# Altium schematic sheet Cell5_Management.SchDoc
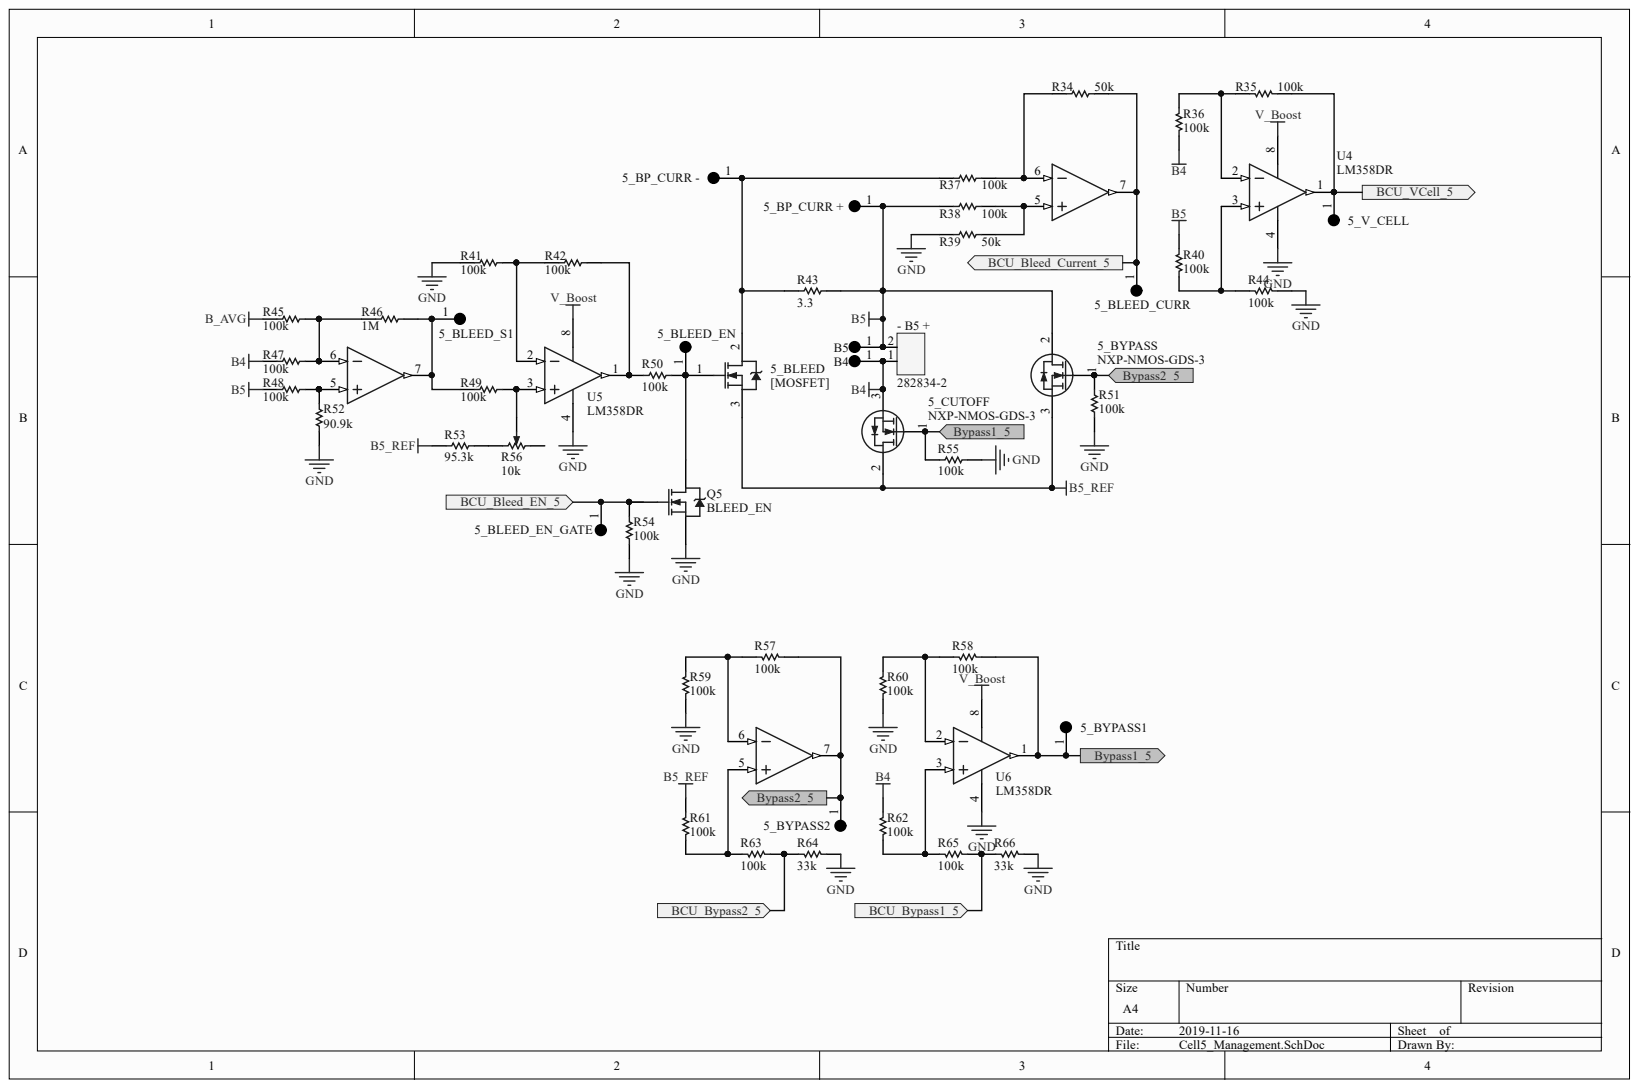
[diagram p0: Cell5_Management.SchDoc]

## Parts
5_BLEED  [MOSFET]  [PCB-8xisw4wyyimpbfb4c87f-1]
5_BLEED_CURR  Keystone 5011  [PCB-nje03k9v44gt2snsz3w9-1]  (value not drawn)
5_BLEED_EN  Keystone 5011  [PCB-nje03k9v44gt2snsz3w9-1]  (value not drawn)
5_BLEED_EN_GATE  Keystone 5011  [PCB-nje03k9v44gt2snsz3w9-1]  (value not drawn)
5_BLEED_S1  Keystone 5011  [PCB-nje03k9v44gt2snsz3w9-1]  (value not drawn)
5_BP_CURR_+  Keystone 5011  [PCB-nje03k9v44gt2snsz3w9-1]  (value not drawn)
5_BP_CURR_-  Keystone 5011  [PCB-nje03k9v44gt2snsz3w9-1]  (value not drawn)
5_BYPASS  NXP-NMOS-GDS-3  [PCB-oiskee3ochvx8romhs33-1]
5_BYPASS1  Keystone 5011  [PCB-nje03k9v44gt2snsz3w9-1]  (value not drawn)
5_BYPASS2  Keystone 5011  [PCB-nje03k9v44gt2snsz3w9-1]  (value not drawn)
5_CUTOFF  NXP-NMOS-GDS-3  [PCB-oiskee3ochvx8romhs33-1]
5_V_CELL  Keystone 5011  [PCB-nje03k9v44gt2snsz3w9-1]  (value not drawn)
-_B5_+  282834-2  [PCB-6xrngco598u3mra2uzi2-1]
B4  Keystone 5011  [PCB-nje03k9v44gt2snsz3w9-1]  (value not drawn)
B5  Keystone 5011  [PCB-nje03k9v44gt2snsz3w9-1]  (value not drawn)
Q5  BLEED_EN  [PCB-nw73lpgy3xhhfuwv1f85-1]
R34  50k  [PCB-q5zalbbyn314pt83xa2y-1]
R35  100k  [PCB-log9y0wuy6o5jvxe2v7d-1]
R36  100k  [PCB-log9y0wuy6o5jvxe2v7d-1]
R37  100k  [PCB-log9y0wuy6o5jvxe2v7d-1]
R38  100k  [PCB-log9y0wuy6o5jvxe2v7d-1]
R39  50k  [PCB-q5zalbbyn314pt83xa2y-1]
R40  100k  [PCB-log9y0wuy6o5jvxe2v7d-1]
R41  100k  [PCB-log9y0wuy6o5jvxe2v7d-1]
R42  100k  [PCB-log9y0wuy6o5jvxe2v7d-1]
R43  3.3  [PCB-kmpuyklh4rdx0el4hoky-1]
R44  100k  [PCB-log9y0wuy6o5jvxe2v7d-1]
R45  100k  [PCB-log9y0wuy6o5jvxe2v7d-1]
R46  1M  [RESC1608X55X25LL10T15]
R47  100k  [PCB-log9y0wuy6o5jvxe2v7d-1]
R48  100k  [PCB-log9y0wuy6o5jvxe2v7d-1]
R49  100k  [PCB-log9y0wuy6o5jvxe2v7d-1]
R50  100k  [PCB-8m9rktjyp1k274dv6t06-1]
R51  100k  [PCB-8m9rktjyp1k274dv6t06-1]
R52  90.9k  [PCB-7hducyzywnmpas6d05r4-1]
R53  95.3k  [PCB-5bx08r7oacs1hl5kejmf-1]
R54  100k  [PCB-8m9rktjyp1k274dv6t06-1]
R55  100k  [PCB-8m9rktjyp1k274dv6t06-1]
R56  10k  [PCB-mtzb08s5emfe72ahohl4-1]
R57  100k  [PCB-log9y0wuy6o5jvxe2v7d-1]
R58  100k  [PCB-log9y0wuy6o5jvxe2v7d-1]
R59  100k  [PCB-log9y0wuy6o5jvxe2v7d-1]
R60  100k  [PCB-log9y0wuy6o5jvxe2v7d-1]
R61  100k  [PCB-log9y0wuy6o5jvxe2v7d-1]
R62  100k  [PCB-log9y0wuy6o5jvxe2v7d-1]
R63  100k  [PCB-log9y0wuy6o5jvxe2v7d-1]
R64  33k  [PCB-a32f45k18f9nc8v92p4b-1]
R65  100k  [PCB-log9y0wuy6o5jvxe2v7d-1]
R66  33k  [PCB-a32f45k18f9nc8v92p4b-1]
U4  LM358DR  [PCB-5j1ieh3zn6lddoji8et2-1]
U5  LM358DR  [PCB-5j1ieh3zn6lddoji8et2-1]
U6  LM358DR  [PCB-5j1ieh3zn6lddoji8et2-1]

## Ports
BCU_BLEED_CURRENT_5, BCU_BLEED_EN_5, BCU_BYPASS1_5, BCU_BYPASS2_5, BCU_VCELL_5, BYPASS1_5, BYPASS2_5

## Nets
B4: 5_CUTOFF.3 -_B5_+.1 B4.1 R36.2 R47.1 R62.2
B5: 5_BP_CURR_+.1 5_BYPASS.2 -_B5_+.2 B5.1 R38.1 R40.2 R43.2 R48.1
B5_REF: 5_BLEED.3 5_BYPASS.3 5_CUTOFF.2 R53.1 R61.2
B_AVG: R45.1
GND: Q5.2 R39.2 R41.1 R44.1 R51.1 R52.2 R54.1 R55.1 R59.2 R60.2 R64.1 R66.1 U4.4 U5.4 U6.4
V_BOOST: U4.8 U5.8 U6.8
Net5_BLEED_1: 5_BLEED.1 5_BLEED_EN.1 Q5.3 R50.1
Net5_BLEED_2: 5_BLEED.2 5_BP_CURR_-.1 R37.1 R43.1
Net5_BLEED_CURR_1: 5_BLEED_CURR.1 R34.1 U4.7 ; port BCU_BLEED_CURRENT_5
Net5_BLEED_EN_GATE_1: 5_BLEED_EN_GATE.1 Q5.1 R54.2 ; port BCU_BLEED_EN_5
Net5_BLEED_S1_1: 5_BLEED_S1.1 R46.2 R49.1 U5.7
Net5_BYPASS1_1: 5_BYPASS1.1 5_CUTOFF.1 R55.2 R58.1 U6.1 ; port BYPASS1_5
Net5_BYPASS2_1: 5_BYPASS.1 5_BYPASS2.1 R51.2 R57.1 U6.7 ; port BYPASS2_5
Net5_V_CELL_1: 5_V_CELL.1 R35.1 U4.1 ; port BCU_VCELL_5
NetR34_2: R34.2 R37.2 U4.6
NetR35_2: R35.2 R36.1 U4.2
NetR38_2: R38.2 R39.1 U4.5
NetR40_1: R40.1 R44.2 U4.3
NetR41_2: R41.2 R42.1 U5.2
NetR42_2: R42.2 R50.2 U5.1
NetR45_2: R45.2 R46.1 R47.2 U5.6
NetR48_2: R48.2 R52.1 U5.5
NetR49_2: R49.2 R56.2 U5.3
NetR53_2: R53.2 R56.1
NetR57_2: R57.2 R59.1 U6.6
NetR58_2: R58.2 R60.1 U6.2
NetR61_1: R61.1 R63.1 U6.5
NetR62_1: R62.1 R65.1 U6.3
NetR63_2: R63.2 R64.2 ; port BCU_BYPASS2_5
NetR65_2: R65.2 R66.2 ; port BCU_BYPASS1_5

Not connected: R56 3

## Library data of the parts
5_BLEED = CMP-830963-4: N-channel 60 V, 0.014 Ohm typ., 35 A StripFET II Power MOSFET in a TO-220 package; Manufacturer Part Number: STP55NF06L; Breakdown Voltage (Drain to Source): 60.0 V; Breakdown Voltage [Gate to Source]: -16.0 V to 16.0 V; Continuous Drain Current (Ids): 55.0 A; Drain to Source Resistance (on) (Rds): 14.0 mΩ; Lead-Free Status: Lead Free; Power Dissipation: 95.0 W; Case/Package: TO-220; Component Kind: Standard; Current Rating: 55.0 A; Drain to Source Voltage (Vds): 60.0 V; Library Name: CommunityVault; Lifecycle Status: Active; Mounting Style: Through Hole; Number of Pins: 3; OctopartID: c4fb0df4d8ec1b3c; Octopart URL: http://octopart.com/stp55nf06l-stmicroelectronics-342385; Packaging: Tube; Polarity: N-Channel; REACH SVHC Compliance: No SVHC; RoHS: Compliant; Voltage Rating (DC): 60.0 V
5_BLEED_CURR 5_BLEED_EN 5_BLEED_EN_GATE 5_BLEED_S1 5_BP_CURR_+ 5_BP_CURR_- 5_BYPASS1 5_BYPASS2 5_V_CELL B4 B5 = 5011: Test Point; Black; Thru-Hole; Snap-Fit; SilverPlate; 0.063in. Dia.; 0.445In.; Component Kind: Net Tie; Library Name: Octopart library
5_BYPASS 5_CUTOFF = CMP-829872-4: N-Channel Logic Level MOSFET, 30 V, 30 A, 3-Pin SOT78, Tube; Manufacturer: NXP Semiconductors; Pakcage: TO-220; Rated Voltage (Vdss): 30 V; Component Kind: Standard; Library Name: CommunityVault; Lifecycle Status: Active; Number of Pins: 3; Octopart Url: https://octopart.com/psmn022-30pl%2C127-nxp+semiconductors-18837202; Polarity: N-Channel
-_B5_+ = 282834-2: Wire-To-Board Terminal Block, 2, 150 V, 10 A, 30 Awg, 16 Awg, 1.4 Mm; ELV Compliant: Compliant; Lead-Free Status: Lead Free; Number of Contacts: 2; Wire Gauge: 16.0 AWG to 30.0 AWG; Color: Green; Component Kind: Standard; Contact Material: Brass; Contact Plating: Tin; Flammability Rating: UL 94 V-0; Library Name: Octopart library; Mounting Style: Screw, Through Hole; Octopart URL: https://octopart.com/282834-2-te+connectivity-46506870; RoHS: Compliant; Size-Width: 6.50 mm
Q5 = DMN65D8L-7: Trans MOSFET N-CH 60V 0.31A Automotive 3-Pin SOT-23 T/R; Rds On (Max) @ Id, Vgs: 3 Ohm @ 115mA, 10V; Component Kind: Standard; Library Name: Octopart library; Lifecycle Status: Active; OctopartID: b9a271de0f056665; Octopart URL: http://octopart.com/dmn65d8l-7-diodes+inc.-24548289; REACH SVHC Compliance: No SVHC
R34 R39 = ERA-3AEB4992V: RES SMD 49.9KOHM 0.1% 1/10W 0603; Manufacturer: Panasonic Electronic Components; Vendor Part Number: P49.9KDBCT-ND; Power (Watts): 0.1W, 1/10W; Resistance: 49.9k; Temperature Coefficient: ±25ppm/°C; Vendor: Digikey; Category: Resistors; Component Kind: Standard; Composition: Thin Film; Cost: 0.53; Family: Chip Resistor - Surface Mount; Features: Automotive AEC-Q200; Height: 0.022" (0.55mm); HelpURL: http://media.digikey.com/pdf/Data%20Sheets/Panasonic%20Electronic%20Components/ERA%201A,2A,3A,6A,8A.pdf; Library Name: Octopart library; Manufacturer Part Number: ERA-3AEB4992V; OctopartID: 7034ba6ea831aff3; Octopart URL: http://octopart.com/era-3aeb4992v-panasonic-55639395; Operating Temperature: -55°C ~ 155°C; Package / Case: 0603 (1608 Metric); Size / Dimension: 0.063" L x 0.031" W (1.60mm x 0.80mm); Supplier Device Package: 0603; Tolerance: ±0.1%
R35-R38 R40-R42 R44 R45 R47-R49 R57-R63 R65 = CMP-bnjbs9wz50zo2virqymb-1: RES SMD 100K OHM 0.1% 1/5W 0603; Component Kind: Standard; CustomComponentID: bnjbs9wz50zo2virqymb; Library Name: FavoritesLibrary
R43 = CMP-9119595501f04ede-2: In a Pack of 5, TE Connectivity 3.3? 5W Wire Wound Resistor ±5% ±300ppm/°C SQMW53R3J; ELV Compliant: Compliant; Lead-Free Status: Lead Free; Lead Length: 3.50 mm; Power Rating: 5.00 W; Resistance: 3.30 ?; Temperature Coefficient: -300 ppm/°C to 300 ppm/°C; Voltage Rating (DC): 350 V; Component Kind: Standard; Library Name: FavoritesLibrary; Lifecycle Status: Active; Manufacturer Part Number: SQMW53R3J; Mounting Style: Radial; OctopartID: 9119595501f04ede; Octopart URL: https://octopart.com/sqmw53r3j-te+connectivity-21411215; Operating Temperature: 275 °C (max); Packaging: Box; REACH SVHC Compliance: No SVHC; Resistance Tolerance: ±5%; RoHS: Compliant
R46 = RC0603FR-071ML: Chip Resistor, 1 MOhm, +/- 1%, 100 mW, -55 to 155 degC, 0603 (1608 Metric), RoHS, Tape and Reel; Lead-Free Status: Lead Free; Resistance: 1.00 MΩ; Component Kind: Standard; Library Name: Octopart library; Manufacturer Part Number: RC0603FR-071ML; Mounting Style: Surface Mount; OctopartID: b2787b63430c6dbf; Octopart Url: http://octopart.com/rc0603fr-071ml-yageo-1168402; PackageDescription: 2-Pin Surface Mount Device, Body 1.6 x 0.8 mm; PackageReference: 0603; Resistance Tolerance: ±1%; Seller Landing  Url: https://octopart-clicks.com/click/track?country=US&ak=8157f166&sig=0fad55c&sid=1106&ppid=1168402&vpid=198667148&ct=offers; Size-Width: 800 µm
R50 R51 R54 R55 = CRGCQ0603J100K: Res, Auto, Thick Film, 100K, 5%, 0603; Component Kind: Standard; Library Name: Octopart library; Lifecycle Status: Not Listed by Manufacturer
R52 = CMP-g689s3hq4ilknvlda8uo-1: RES SMD 90.9K OHM 0.1% 1/5W 0603; Component Kind: Standard; CustomComponentID: g689s3hq4ilknvlda8uo; Library Name: FavoritesLibrary
R53 = CMP-32d8f9a6adc0ed1f-2: Res Thick Film 0603 95.3K Ohm 1% 0.1W(1/10W) ±100ppm/C Pad SMD T/R; Manufacturer Part Number: RC0603FR-0795K3L; Case/Package (SI): 1608; Lead-Free Status: Lead Free; Power Rating: 100 mW; Resistance: 95.3 k?; Temperature Coefficient: -100 ppm/°C to 100 ppm/°C; Voltage Rating (DC): 50.0 V; Case/Package: 0603; Component Kind: Standard; Library Name: FavoritesLibrary; Mounting Style: Surface Mount; OctopartID: 32d8f9a6adc0ed1f; Octopart URL: https://octopart.com/rc0603fr-0795k3l-yageo-41204350; Operating Temperature: 155 °C (max); Packaging: Tape & Reel; Resistance Tolerance: ±1%; RoHS: Compliant; Size-Height: 450 µm; Size-Length: 1.60 mm; Size-Width: 800 µm
R56 = CMP-1e9bd3d2522ac15c-1: Res Cermet Trimmer 10K Ohm 10% 1/2W 25(Elec)Turn 2mm (9.6 X 5 X 11.3mm) Pin Thru-Hole Bulk; Lead-Free Status: Lead Free; Resistance: 10.0 kΩ; Component Kind: Standard; Library Name: CommunityVault; Octopart URL: http://octopart.com/pv36w103c01b00-bourns-71526871; REACH SVHC Compliance: No SVHC; RoHS: Compliant; Simulation: SIM-i4psbru60z8t73qiz1cu-1
R64 R66 = ERJ3EKF3302V: Res Thick Film 0603 33K Ohm 1% 0.1W(1/10W) ±100ppm/C Molded SMD Automotive Punched T/R; Manufacturer Part Number: ERJ-3EKF3302V; Lead-Free Status: Lead Free; Power Rating: 100 mW; Resistance: 33 KΩ; Voltage Rating (DC): 75.0 V; Case/Package: 0603; Component Kind: Standard; Library Name: Octopart library; Lifecycle Status: Not Listed by Manufacturer; Mounting Style: Surface Mount; Number of Pins: 2; OctopartID: 11b7d802b8e0ce70; Octopart URL: http://octopart.com/erj-3ekf3302v-panasonic-12553265; Packaging: Tape & Reel (TR), Cut Tape (CT); REACH SVHC Compliance: No SVHC; Resistance Tolerance: ±1%; RoHS: Compliant
U4-U6 = CMP-4gajgemt6408qmd47hs9-2: 8-SOIC Dual Op-Amp; Component Kind: Standard; CustomComponentID: 4gajgemt6408qmd47hs9; Library Name: FavoritesLibrary
